# Altium schematic sheet remote_controll_main(V1.3).SchDoc(remote_controll_main(V1.3))
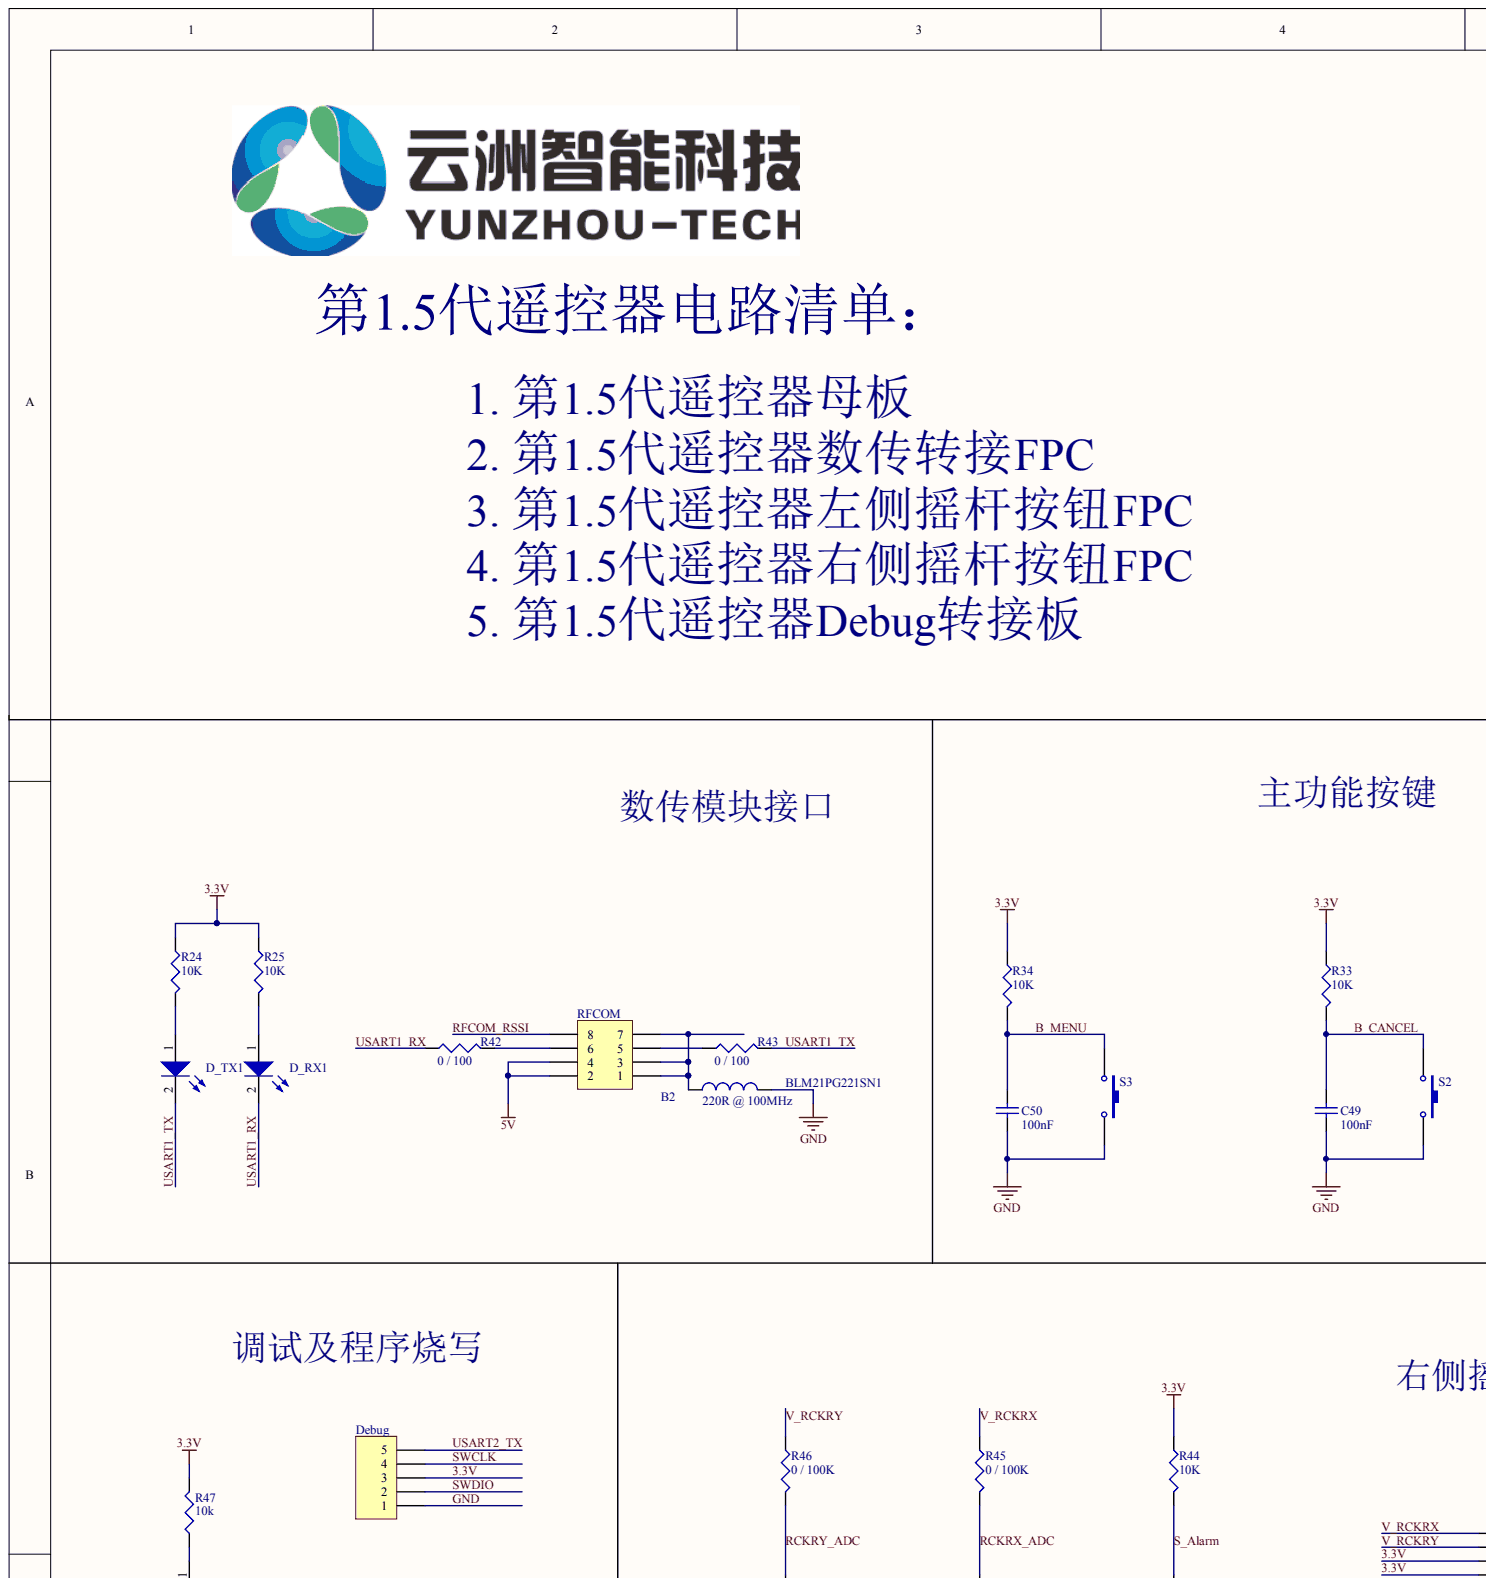
[diagram p0: remote_controll_main, top left]
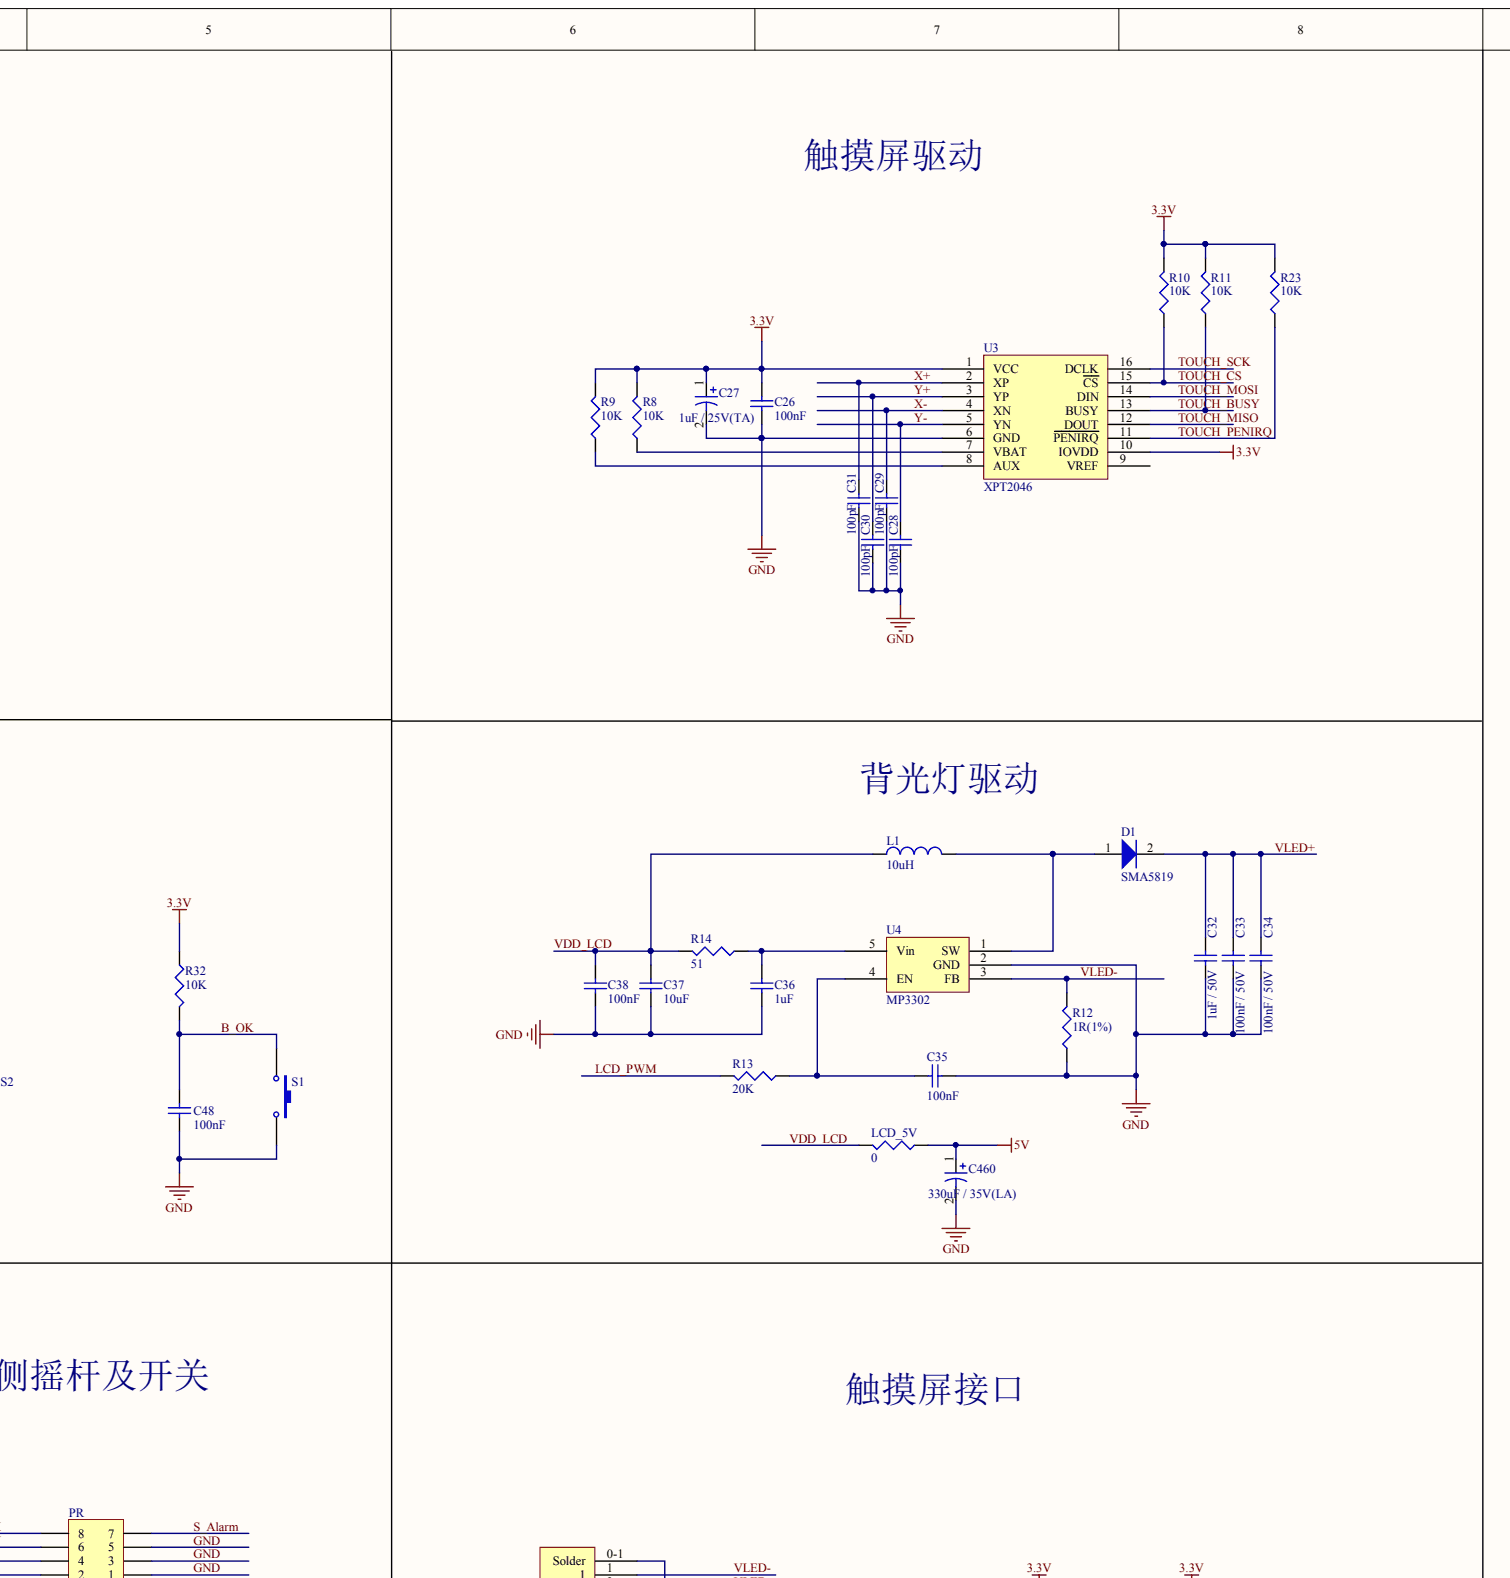
[diagram p1: remote_controll_main, top centre]
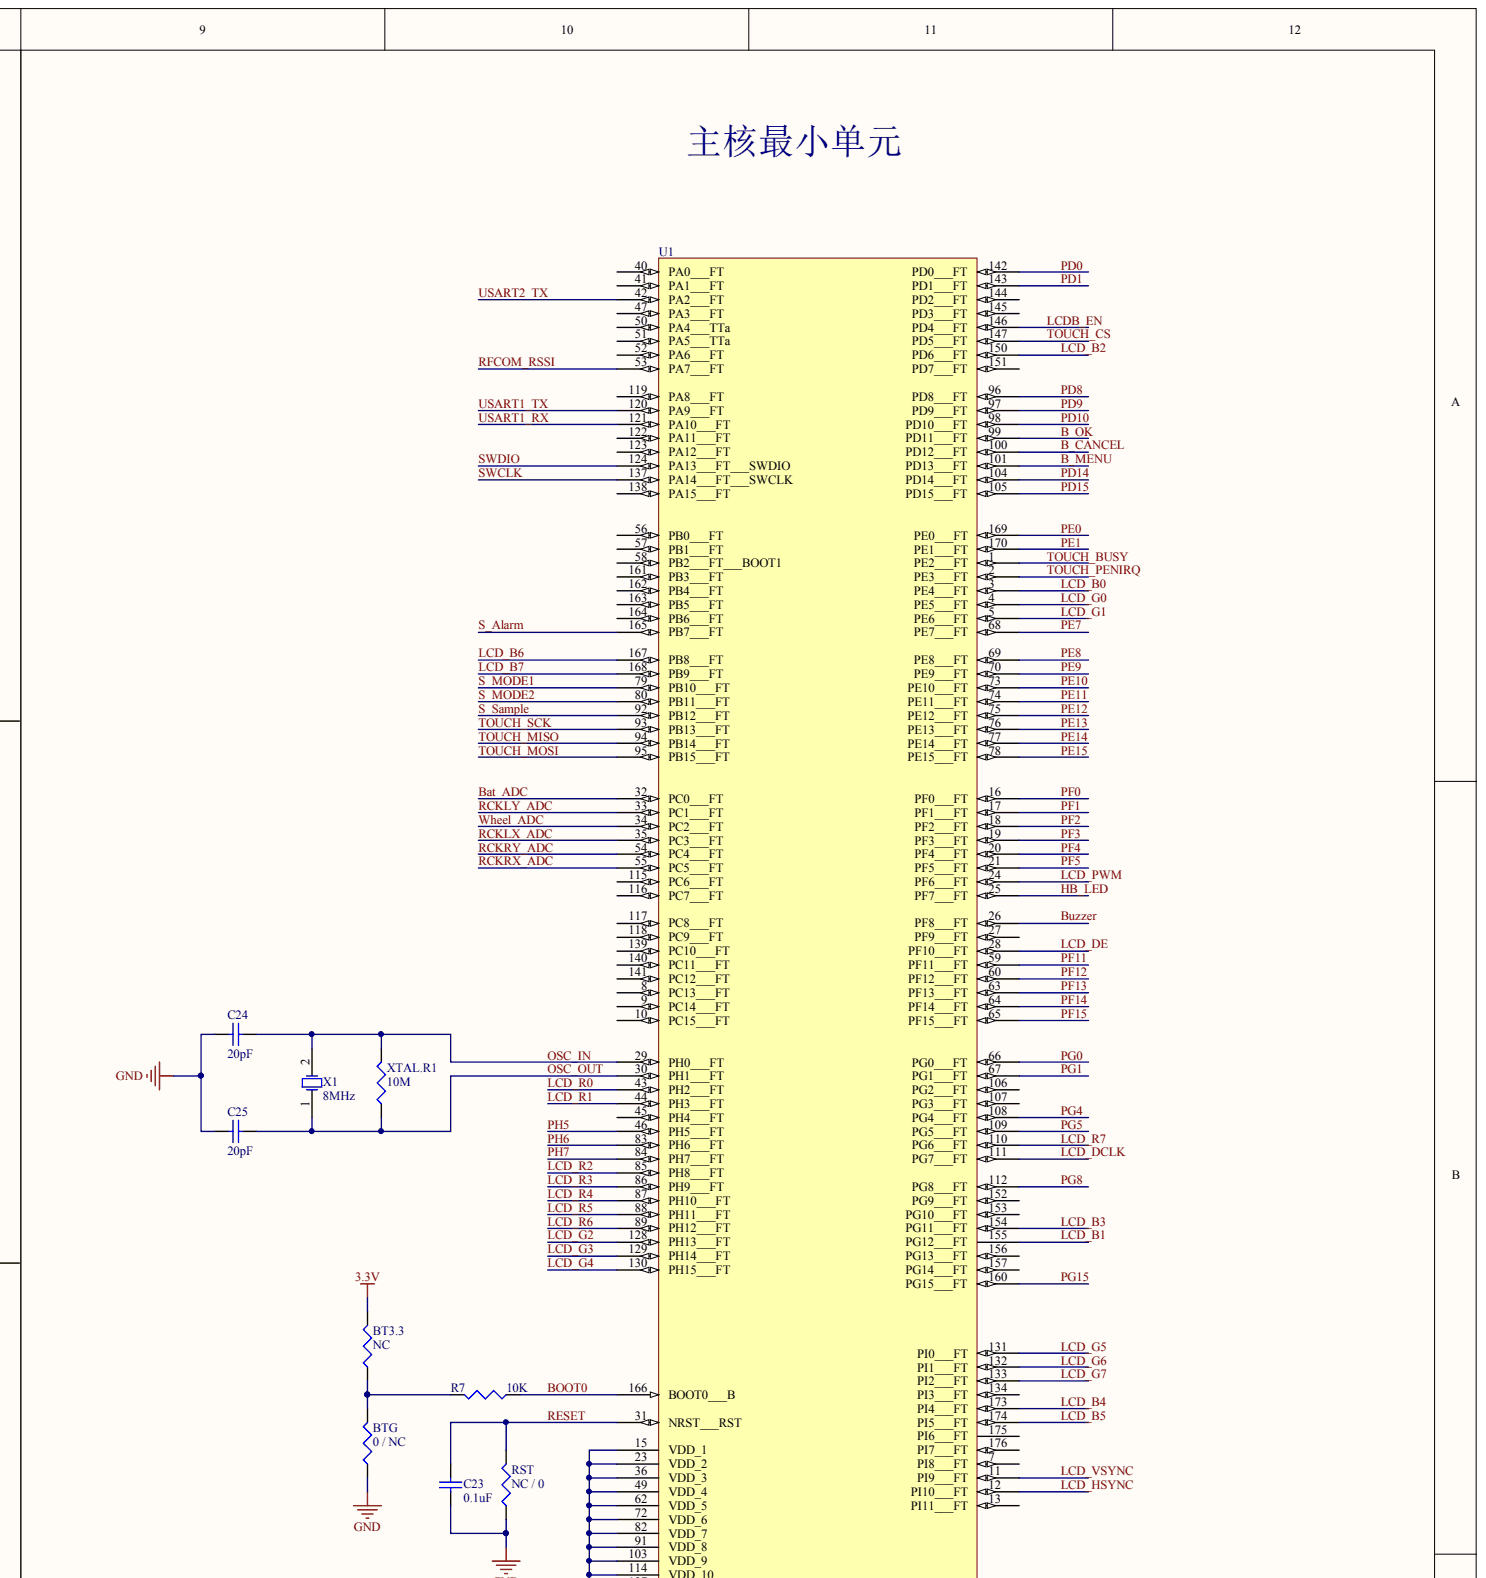
[diagram p2: remote_controll_main, top right]
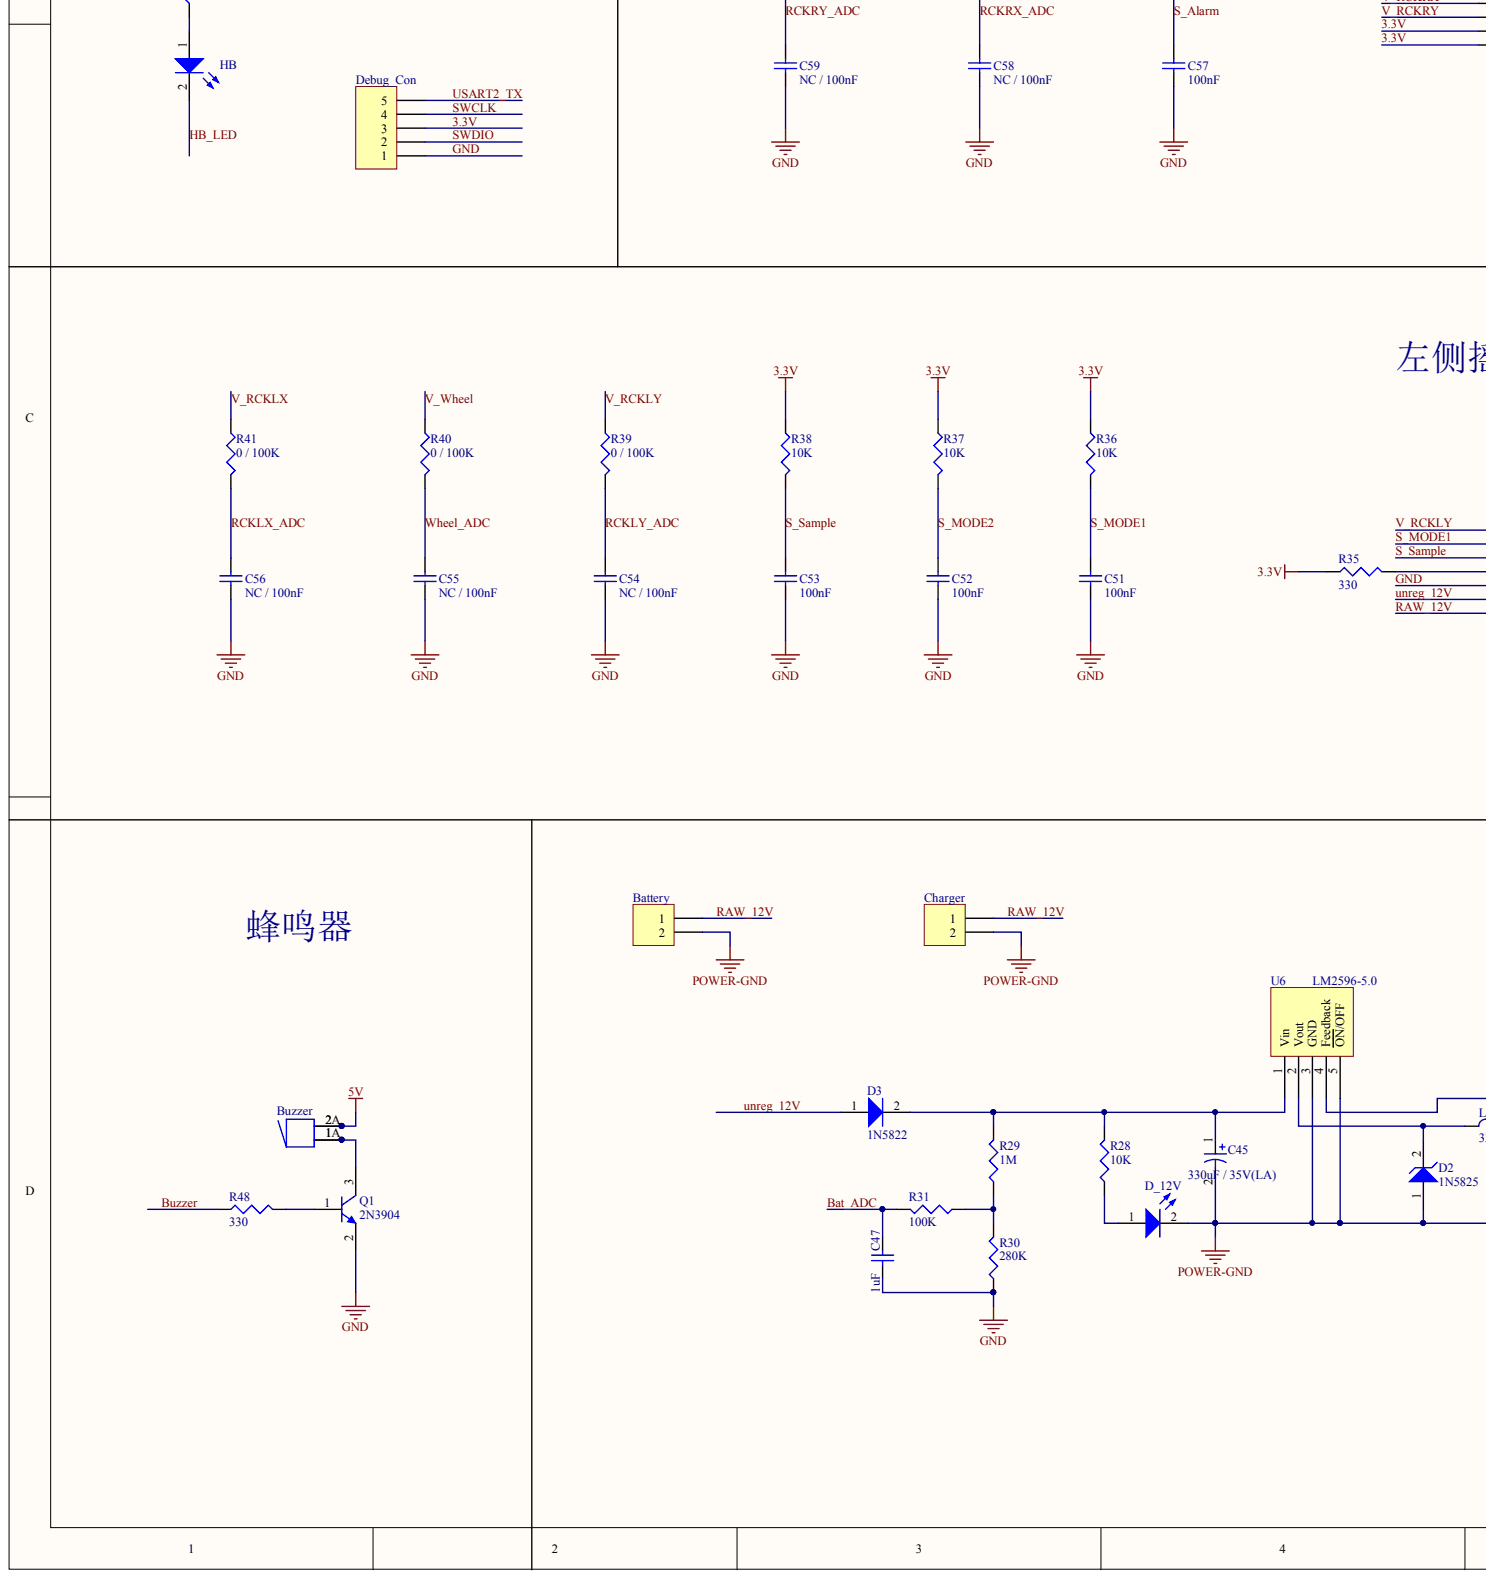
[diagram p3: remote_controll_main, bottom left]
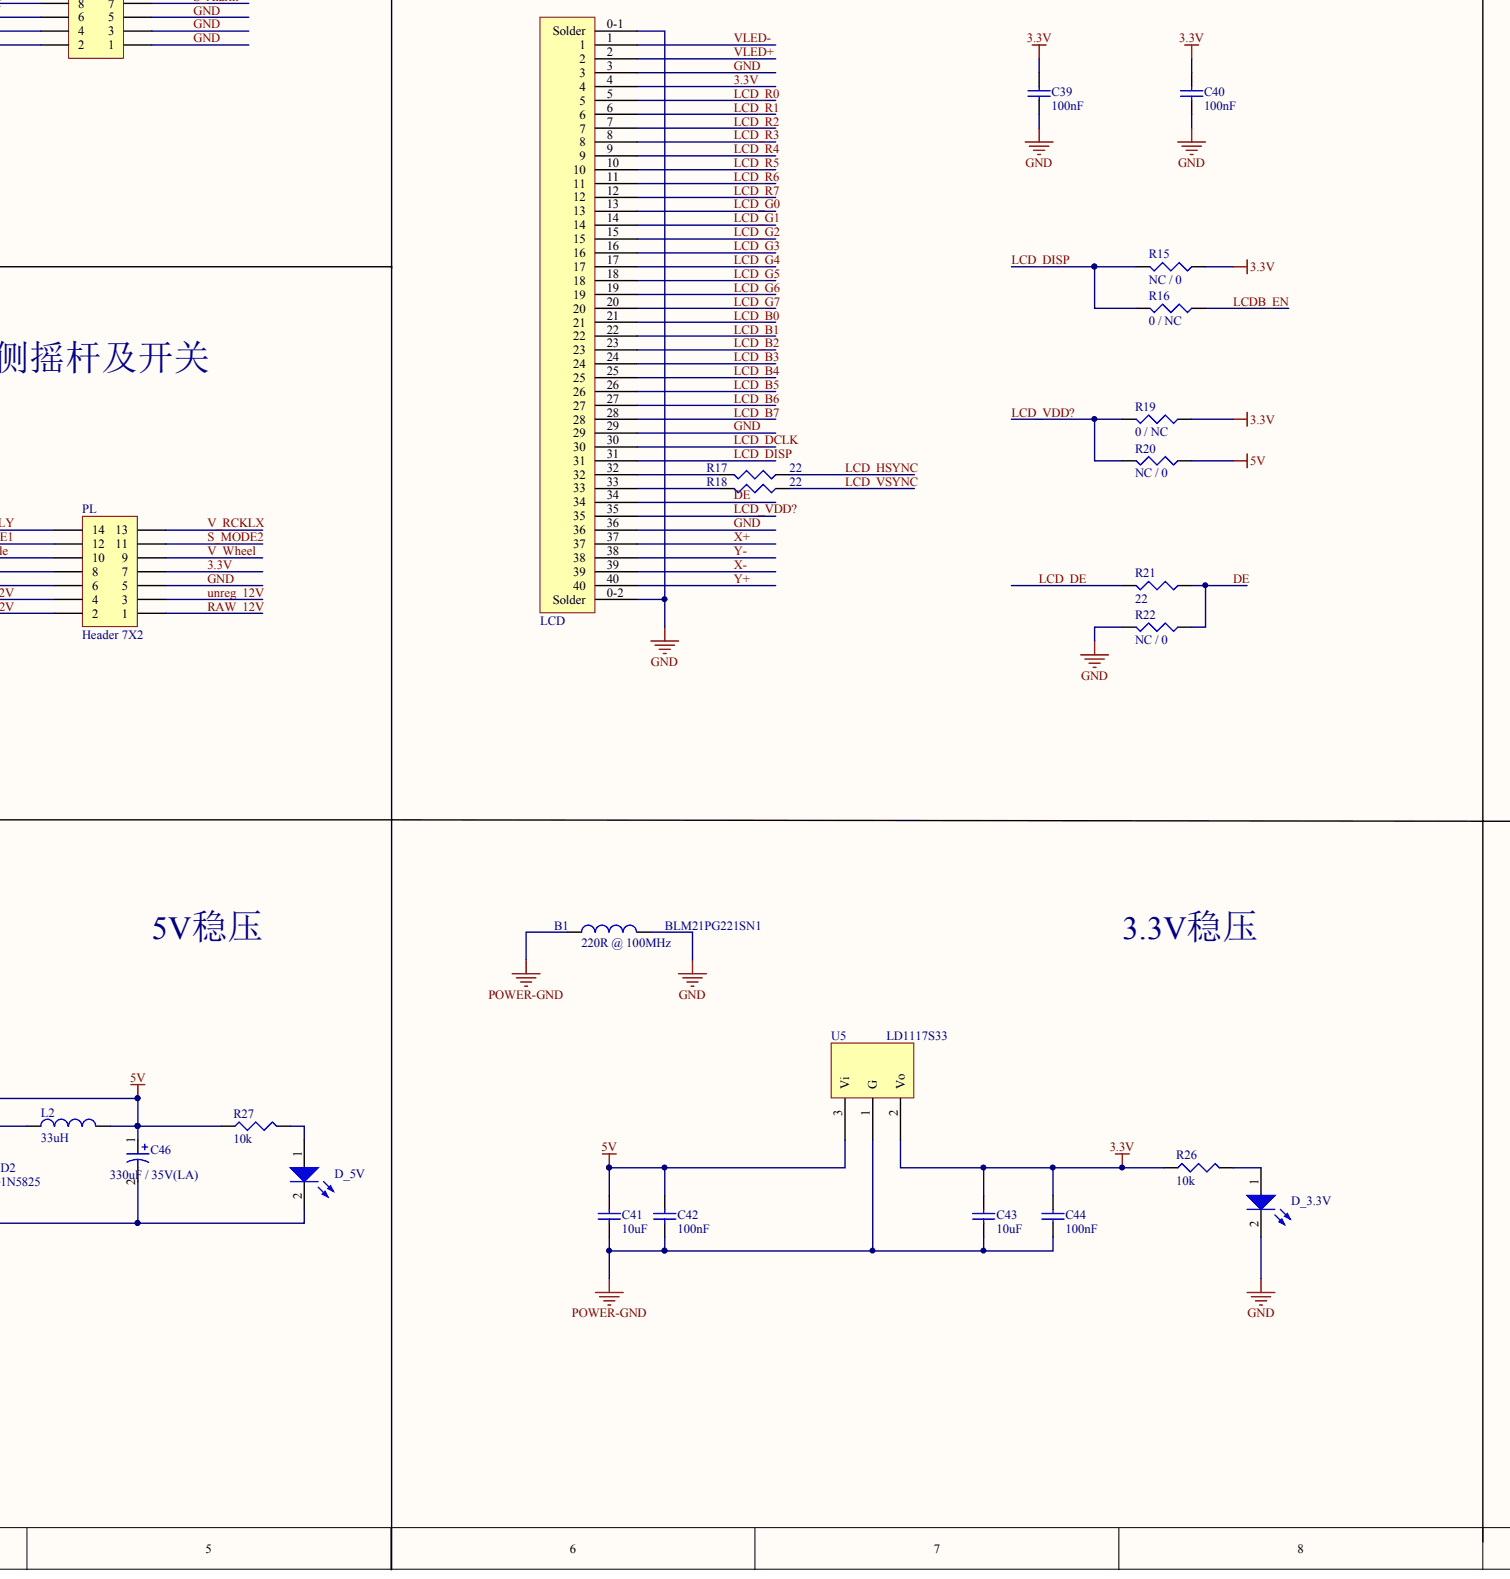
[diagram p4: remote_controll_main, bottom centre]
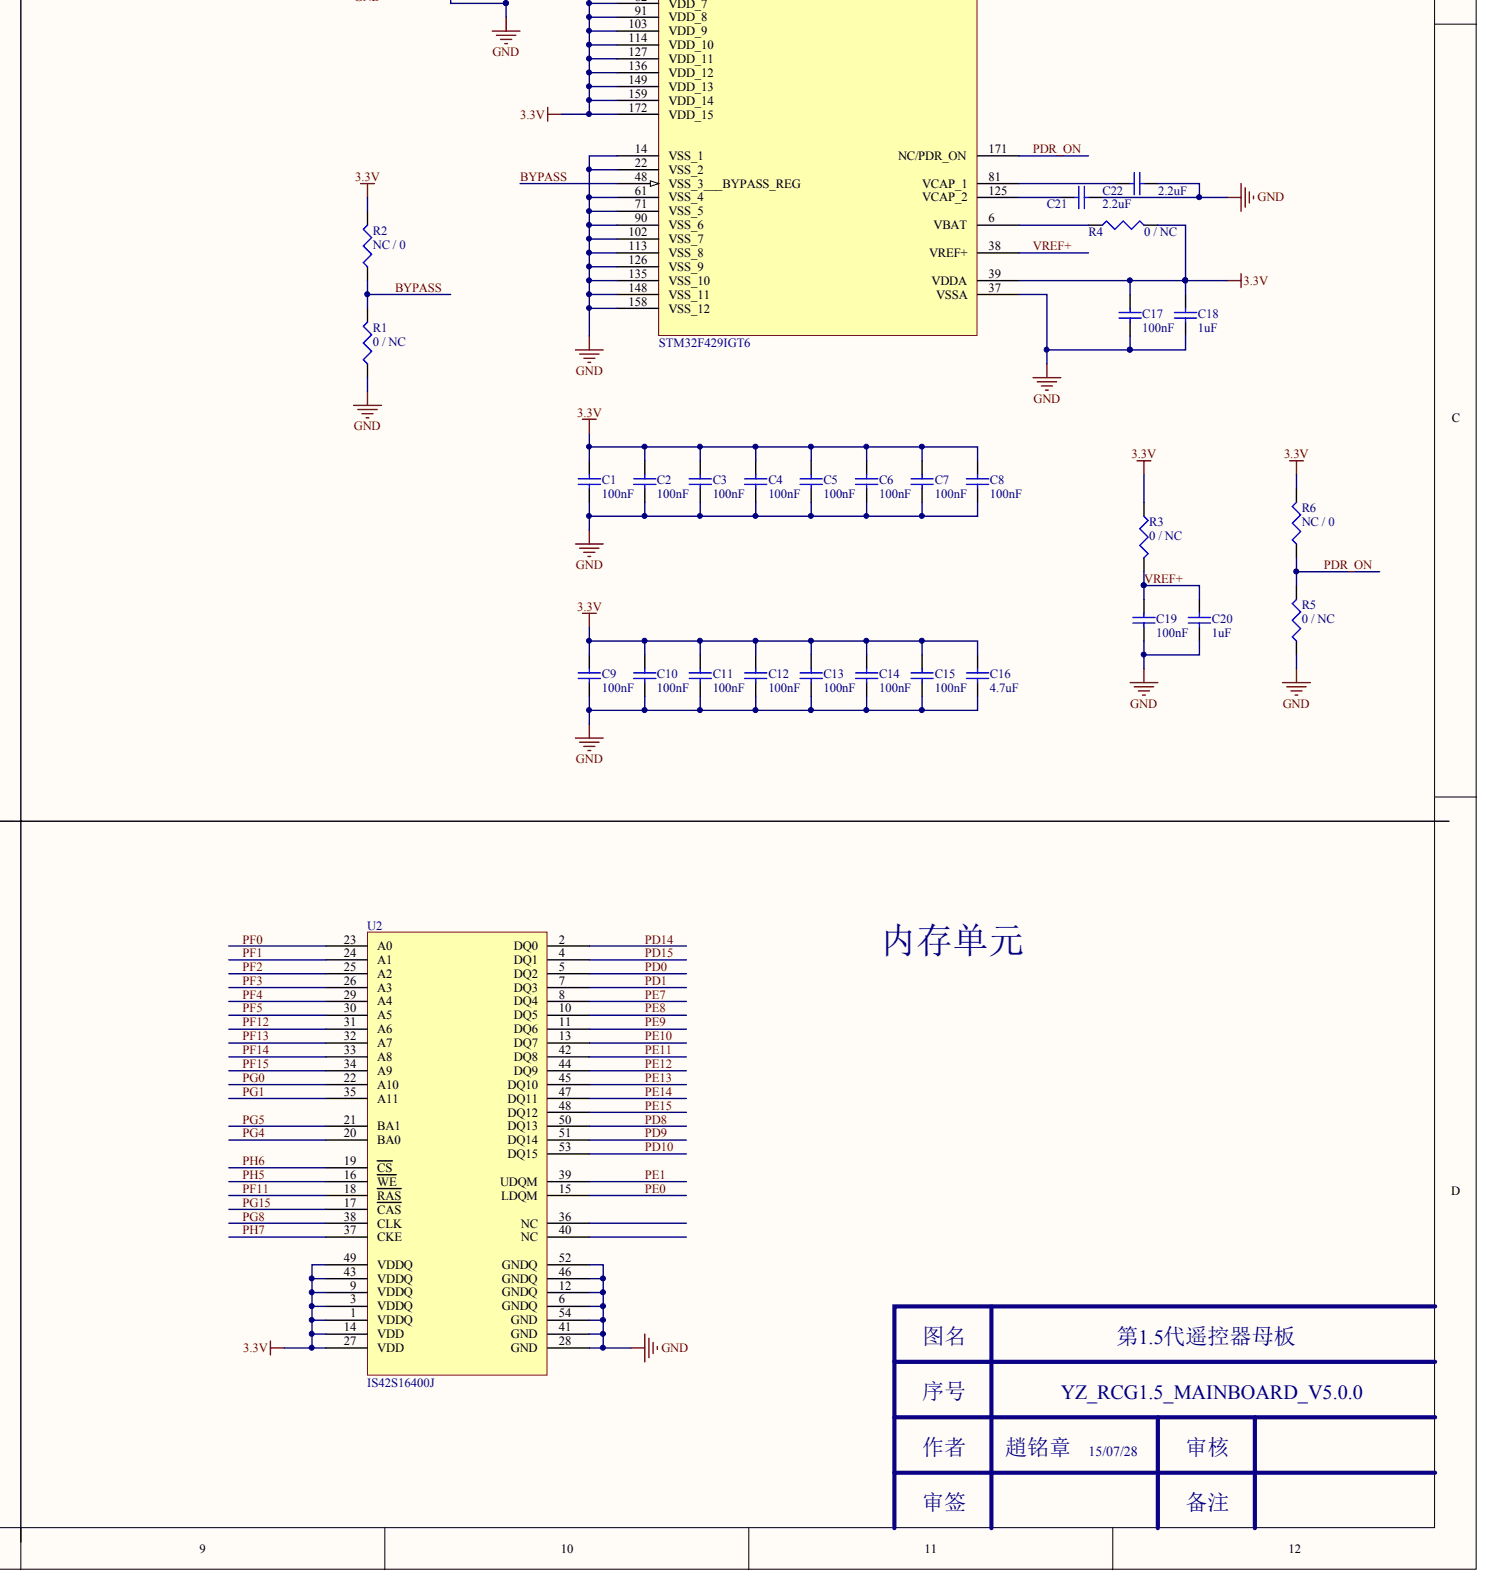
[diagram p5: remote_controll_main, bottom right]

## Parts
B1  BLM21PG221SN1  value 220R @ 100MHz
B2  BLM21PG221SN1  value 220R @ 100MHz
Battery  Header 2  (value not drawn)
BT3.3  value NC  (hidden comment: Res3)
BTG  value 0 / NC  (hidden comment: Res3)
Buzzer  Buzzer
C1  value 100nF  (hidden comment: Cap Semi)
C2  value 100nF  (hidden comment: Cap Semi)
C3  value 100nF  (hidden comment: Cap Semi)
C4  value 100nF  (hidden comment: Cap Semi)
C5  value 100nF  (hidden comment: Cap Semi)
C6  value 100nF  (hidden comment: Cap Semi)
C7  value 100nF  (hidden comment: Cap Semi)
C8  value 100nF  (hidden comment: Cap Semi)
C9  value 100nF  (hidden comment: Cap Semi)
C10  value 100nF  (hidden comment: Cap Semi)
C11  value 100nF  (hidden comment: Cap Semi)
C12  value 100nF  (hidden comment: Cap Semi)
C13  value 100nF  (hidden comment: Cap Semi)
C14  value 100nF  (hidden comment: Cap Semi)
C15  value 100nF  (hidden comment: Cap Semi)
C16  value 4.7uF  (hidden comment: Cap Semi)
C17  value 100nF  (hidden comment: Cap Semi)
C18  value 1uF  (hidden comment: Cap Semi)
C19  value 100nF  (hidden comment: Cap Semi)
C20  value 1uF  (hidden comment: Cap Semi)
C21  value 2.2uF  (hidden comment: Cap Semi)
C22  value 2.2uF  (hidden comment: Cap Semi)
C23  value 0.1uF  (hidden comment: Cap Semi)
C24  value 20pF  (hidden comment: Cap Semi)
C25  value 20pF  (hidden comment: Cap Semi)
C26  value 100nF  (hidden comment: Cap Semi)
C27  value 1uF / 25V(TA)  (hidden comment: Cap Pol3)
C28  value 100pF  (hidden comment: Cap Semi)
C29  value 100pF  (hidden comment: Cap Semi)
C30  value 100pF  (hidden comment: Cap Semi)
C31  value 100pF  (hidden comment: Cap Semi)
C32  value 1uF / 50V  (hidden comment: Cap Semi)
C33  value 100nF / 50V  (hidden comment: Cap Semi)
C34  value 100nF / 50V  (hidden comment: Cap Semi)
C35  value 100nF  (hidden comment: Cap Semi)
C36  value 1uF  (hidden comment: Cap Semi)
C37  value 10uF  (hidden comment: Cap Semi)
C38  value 100nF  (hidden comment: Cap Semi)
C39  value 100nF  (hidden comment: Cap Semi)
C40  value 100nF  (hidden comment: Cap Semi)
C41  value 10uF  (hidden comment: Cap Semi)
C42  value 100nF  (hidden comment: Cap Semi)
C43  value 10uF  (hidden comment: Cap Semi)
C44  value 100nF  (hidden comment: Cap Semi)
C45  value 330uF / 35V(LA)  (hidden comment: Cap Pol3)
C46  value 330uF / 35V(LA)  (hidden comment: Cap Pol3)
C47  value 1uF  (hidden comment: Cap Semi)
C48  value 100nF  (hidden comment: Cap Semi)
C49  value 100nF  (hidden comment: Cap Semi)
C50  value 100nF  (hidden comment: Cap Semi)
C51  value 100nF  (hidden comment: Cap Semi)
C52  value 100nF  (hidden comment: Cap Semi)
C53  value 100nF  (hidden comment: Cap Semi)
C54  value NC / 100nF  (hidden comment: Cap Semi)
C55  value NC / 100nF  (hidden comment: Cap Semi)
C56  value NC / 100nF  (hidden comment: Cap Semi)
C57  value 100nF  (hidden comment: Cap Semi)
C58  value NC / 100nF  (hidden comment: Cap Semi)
C59  value NC / 100nF  (hidden comment: Cap Semi)
C460  value 330uF / 35V(LA)  (hidden comment: Cap Pol3)
Charger  Header 2  (value not drawn)
D1  SMA5819
D2  1N5825
D3  1N5822
D_3.3V  LED3  (value not drawn)
D_5V  LED3  (value not drawn)
D_12V  LED3  (value not drawn)
D_RX1  LED3  (value not drawn)
D_TX1  LED3  (value not drawn)
Debug  Debug
Debug_Con  Debug
HB  LED3  (value not drawn)
L1  value 10uH  (hidden comment: Inductor)
L2  value 33uH  (hidden comment: Inductor)
LCD  FPC_40  (value not drawn)
LCD_5V  value 0  (hidden comment: Res3)
PL  Header 7X2
PR  Header 4X2  (value not drawn)
Q1  2N3904
R1  value 0 / NC  (hidden comment: Res3)
R2  value NC / 0  (hidden comment: Res3)
R3  value 0 / NC  (hidden comment: Res3)
R4  value 0 / NC  (hidden comment: Res3)
R5  value 0 / NC  (hidden comment: Res3)
R6  value NC / 0  (hidden comment: Res3)
R7  value 10K  (hidden comment: Res3)
R8  value 10K  (hidden comment: Res3)
R9  value 10K  (hidden comment: Res3)
R10  value 10K  (hidden comment: Res3)
R11  value 10K  (hidden comment: Res3)
R12  value 1R(1%)  (hidden comment: Res3)
R13  value 20K  (hidden comment: Res3)
R14  value 51  (hidden comment: Res3)
R15  value NC / 0  (hidden comment: Res3)
R16  value 0 / NC  (hidden comment: Res3)
R17  value 22  (hidden comment: Res3)
R18  value 22  (hidden comment: Res3)
R19  value 0 / NC  (hidden comment: Res3)
R20  value NC / 0  (hidden comment: Res3)
R21  value 22  (hidden comment: Res3)
R22  value NC / 0  (hidden comment: Res3)
R23  value 10K  (hidden comment: Res3)
R24  value 10K  (hidden comment: Res3)
R25  value 10K  (hidden comment: Res3)
R26  value 10k  (hidden comment: Res3)
R27  value 10k  (hidden comment: Res3)
R28  value 10K  (hidden comment: Res3)
R29  value 1M  (hidden comment: Res3)
R30  value 280K  (hidden comment: Res3)
R31  value 100K  (hidden comment: Res3)
R32  value 10K  (hidden comment: Res3)
R33  value 10K  (hidden comment: Res3)
R34  value 10K  (hidden comment: Res3)
R35  value 330  (hidden comment: Res3)
R36  value 10K  (hidden comment: Res3)
R37  value 10K  (hidden comment: Res3)
R38  value 10K  (hidden comment: Res3)
R39  value 0 / 100K  (hidden comment: Res3)
R40  value 0 / 100K  (hidden comment: Res3)
R41  value 0 / 100K  (hidden comment: Res3)
R42  value 0 / 100  (hidden comment: Res3)
R43  value 0 / 100  (hidden comment: Res3)
R44  value 10K  (hidden comment: Res3)
R45  value 0 / 100K  (hidden comment: Res3)
R46  value 0 / 100K  (hidden comment: Res3)
R47  value 10k  (hidden comment: Res3)
R48  value 330  (hidden comment: Res3)
RFCOM  Header 4X2  (value not drawn)
RST  value NC / 0  (hidden comment: Res3)
S1  SW-PB  (value not drawn)
S2  SW-PB  (value not drawn)
S3  SW-PB  (value not drawn)
U1  STM32F429IGT6
U2  IS42S16400J
U3  XPT2046
U4  MP3302
U5  LD1117S33
U6  LM2596-5.0
X1  8MHz
XTAL.R1  value 10M  (hidden comment: Res3)

## Nets
5V: Buzzer.2 Buzzer.2A C41.1 C42.1 C46.1 C460.1 L2.2 LCD_5V.1 R20.1 R27.1 RFCOM.2 RFCOM.4 U5.3 U6.4(Feedback)
POWER-GND: B1.1 Battery.2 C41.2 C42.2 C43.2 C44.2 C45.2 C46.2 Charger.2 D2.1 D_5V.2 D_12V.2 U5.1 U6.3(GND) U6.5(ON/OFF)
3.3V: BT3.3.2 C1.2 C2.2 C3.2 C4.2 C5.2 C6.2 C7.2 C8.2 C9.2 C10.2 C11.2 C12.2 C13.2 C14.2 C15.2 C16.2 C17.2 C18.2 C26.2 C27.1 C39.1 C40.1 C43.1 C44.1 Debug.3 Debug_Con.3 LCD.4 PL.7 PR.2 PR.4 R2.2 R3.1 R4.2 R6.2 R8.2 R9.2 R10.2 R11.2 R15.1 R19.1 R23.2 R24.1 R25.1 R26.1 R32.1 R33.1 R34.1 R35.1 R36.1 R37.1 R38.1 R44.1 R47.2 U1.15(VDD_1) U1.23(VDD_2) U1.36(VDD_3) U1.39(VDDA) U1.49(VDD_4) U1.62(VDD_5) U1.72(VDD_6) U1.82(VDD_7) U1.91(VDD_8) U1.103(VDD_9) U1.114(VDD_10) U1.127(VDD_11) U1.136(VDD_12) U1.149(VDD_13) U1.159(VDD_14) U1.172(VDD_15) U2.1(VDDQ) U2.3(VDDQ) U2.9(VDDQ) U2.14(VDD) U2.27(VDD) U2.43(VDDQ) U2.49(VDDQ) U3.1(VCC) U3.10(IOVDD) U5.2
B_CANCEL: C49.2 R33.2 S2.1 U1.100(PD12___FT)
B_MENU: C50.2 R34.2 S3.1 U1.101(PD13___FT)
B_OK: C48.2 R32.2 S1.1 U1.99(PD11___FT)
BAT_ADC: C47.2 R31.2 U1.32(PC0___FT)
BOOT0: R7.1 U1.166(BOOT0___B)
BUZZER: R48.1 U1.26(PF8___FT)
BYPASS: R1.2 R2.1 U1.48(VSS_3___BYPASS_REG)
DE: LCD.34 R21.1 R22.1
GND: B1.2 B2.2 BTG.1 C1.1 C2.1 C3.1 C4.1 C5.1 C6.1 C7.1 C8.1 C9.1 C10.1 C11.1 C12.1 C13.1 C14.1 C15.1 C16.1 C17.1 C18.1 C19.1 C20.1 C21.1 C22.1 C23.2 C24.1 C25.1 C26.1 C27.2 C28.1 C29.1 C30.1 C31.1 C32.1 C33.1 C34.1 C35.1 C36.2 C37.2 C38.2 C39.2 C40.2 C47.1 C48.1 C49.1 C50.1 C51.1 C52.1 C53.1 C54.1 C55.1 C56.1 C57.1 C58.1 C59.1 C460.2 D_3.3V.2 Debug.1 Debug_Con.1 LCD.0-1(Solder) LCD.0-2(Solder) LCD.3 LCD.29 LCD.36 PL.5 PL.6 PR.1 PR.3 PR.5 Q1.2 R1.1 R5.1 R12.1 R22.2 R30.1 RST.1 S1.2 S2.2 S3.2 U1.14(VSS_1) U1.22(VSS_2) U1.37(VSSA) U1.61(VSS_4) U1.71(VSS_5) U1.90(VSS_6) U1.102(VSS_7) U1.113(VSS_8) U1.126(VSS_9) U1.135(VSS_10) U1.148(VSS_11) U1.158(VSS_12) U2.6(GNDQ) U2.12(GNDQ) U2.28(GND) U2.41(GND) U2.46(GNDQ) U2.52(GNDQ) U2.54(GND) U3.6(GND) U4.2(GND)
HB_LED: HB.2 U1.25(PF7___FT)
LCD_B0: LCD.21 U1.3(PE4___FT)
LCD_B1: LCD.22 U1.155(PG12___FT)
LCD_B2: LCD.23 U1.150(PD6___FT)
LCD_B3: LCD.24 U1.154(PG11___FT)
LCD_B4: LCD.25 U1.173(PI4___FT)
LCD_B5: LCD.26 U1.174(PI5___FT)
LCD_B6: LCD.27 U1.167(PB8___FT)
LCD_B7: LCD.28 U1.168(PB9___FT)
LCD_DCLK: LCD.30 U1.111(PG7___FT)
LCD_DE: R21.2 U1.28(PF10___FT)
LCD_DISP: LCD.31 R15.2 R16.2
LCD_G0: LCD.13 U1.4(PE5___FT)
LCD_G1: LCD.14 U1.5(PE6___FT)
LCD_G2: LCD.15 U1.128(PH13___FT)
LCD_G3: LCD.16 U1.129(PH14___FT)
LCD_G4: LCD.17 U1.130(PH15___FT)
LCD_G5: LCD.18 U1.131(PI0___FT)
LCD_G6: LCD.19 U1.132(PI1___FT)
LCD_G7: LCD.20 U1.133(PI2___FT)
LCD_HSYNC: R17.1 U1.12
LCD_PWM: R13.2 U1.24(PF6___FT)
LCD_R0: LCD.5 U1.43(PH2___FT)
LCD_R1: LCD.6 U1.44(PH3___FT)
LCD_R2: LCD.7 U1.85(PH8___FT)
LCD_R3: LCD.8 U1.86(PH9___FT)
LCD_R4: LCD.9 U1.87(PH10___FT)
LCD_R5: LCD.10 U1.88(PH11___FT)
LCD_R6: LCD.11 U1.89(PH12___FT)
LCD_R7: LCD.12 U1.110(PG6___FT)
LCD_VDD?: LCD.35 R19.2 R20.2
LCD_VSYNC: R18.1 U1.11(PI9___FT)
LCDB_EN: R16.1 U1.146(PD4___FT)
OSC_IN: C24.2 U1.29(PH0___FT) X1.2 XTAL.R1.2
OSC_OUT: C25.2 U1.30(PH1___FT) X1.1 XTAL.R1.1
PD0: U1.142(PD0___FT) U2.5(DQ2)
PD1: U1.143(PD1___FT) U2.7(DQ3)
PD8: U1.96(PD8___FT) U2.50(DQ13)
PD9: U1.97(PD9___FT) U2.51(DQ14)
PD10: U1.98(PD10___FT) U2.53(DQ15)
PD14: U1.104(PD14___FT) U2.2(DQ0)
PD15: U1.105(PD15___FT) U2.4(DQ1)
PDR_ON: R5.2 R6.1 U1.171(NC/PDR_ON)
PE0: U1.169(PE0___FT) U2.15(LDQM)
PE1: U1.170(PE1___FT) U2.39(UDQM)
PE7: U1.68(PE7___FT) U2.8(DQ4)
PE8: U1.69(PE8___FT) U2.10(DQ5)
PE9: U1.70(PE9___FT) U2.11(DQ6)
PE10: U1.73(PE10___FT) U2.13(DQ7)
PE11: U1.74(PE11___FT) U2.42(DQ8)
PE12: U1.75(PE12___FT) U2.44(DQ9)
PE13: U1.76(PE13___FT) U2.45(DQ10)
PE14: U1.77(PE14___FT) U2.47(DQ11)
PE15: U1.78(PE15___FT) U2.48(DQ12)
PF0: U1.16(PF0___FT) U2.23(A0)
PF1: U1.17(PF1___FT) U2.24(A1)
PF2: U1.18(PF2___FT) U2.25(A2)
PF3: U1.19(PF3___FT) U2.26(A3)
PF4: U1.20(PF4___FT) U2.29(A4)
PF5: U1.21(PF5___FT) U2.30(A5)
PF11: U1.59(PF11___FT) U2.18(RAS)
PF12: U1.60(PF12___FT) U2.31(A6)
PF13: U1.63(PF13___FT) U2.32(A7)
PF14: U1.64(PF14___FT) U2.33(A8)
PF15: U1.65(PF15___FT) U2.34(A9)
PG0: U1.66(PG0___FT) U2.22(A10)
PG1: U1.67(PG1___FT) U2.35(A11)
PG4: U1.108(PG4___FT) U2.20(BA0)
PG5: U1.109(PG5___FT) U2.21(BA1)
PG8: U1.112(PG8___FT) U2.38(CLK)
PG15: U1.160(PG15___FT) U2.17(CAS)
PH5: U1.46(PH5___FT) U2.16(WE)
PH6: U1.83(PH6___FT) U2.19(CS)
PH7: U1.84(PH7___FT) U2.37(CKE)
RAW_12V: Battery.1 Charger.1 PL.1 PL.2
RCKLX_ADC: C56.2 R41.2 U1.35(PC3___FT)
RCKLY_ADC: C54.2 R39.2 U1.33(PC1___FT)
RCKRX_ADC: C58.2 R45.2 U1.55(PC5___FT)
RCKRY_ADC: C59.2 R46.2 U1.54(PC4___FT)
RESET: C23.1 RST.2 U1.31(NRST___RST)
RFCOM_RSSI: RFCOM.8 U1.53(PA7___FT)
S_ALARM: C57.2 PR.7 R44.2 U1.165(PB7___FT)
S_MODE1: C51.2 PL.12 R36.2 U1.79(PB10___FT)
S_MODE2: C52.2 PL.11 R37.2 U1.80(PB11___FT)
S_SAMPLE: C53.2 PL.10 R38.2 U1.92(PB12___FT)
SWCLK: Debug.4 Debug_Con.4 U1.137(PA14___FT___SWCLK)
SWDIO: Debug.2 Debug_Con.2 U1.124(PA13___FT___SWDIO)
TOUCH_BUSY: R11.1 U1.1(PE2___FT) U3.13(BUSY)
TOUCH_CS: R10.1 U1.147(PD5___FT) U3.15(CS)
TOUCH_MISO: U1.94(PB14___FT) U3.12(DOUT)
TOUCH_MOSI: U1.95(PB15___FT) U3.14(DIN)
TOUCH_PENIRQ: R23.1 U1.2(PE3___FT) U3.11(PENIRQ)
TOUCH_SCK: U1.93(PB13___FT) U3.16(DCLK)
UNREG_12V: D3.1 PL.3 PL.4
USART1_RX: D_RX1.2 R42.1 U1.121(PA10___FT)
USART1_TX: D_TX1.2 R43.2 U1.120(PA9___FT)
USART2_TX: Debug.5 Debug_Con.5 U1.42(PA2___FT)
V_RCKLX: PL.13 R41.1
V_RCKLY: PL.14 R39.1
V_RCKRX: PR.8 R45.1
V_RCKRY: PR.6 R46.1
V_WHEEL: PL.9 R40.1
VDD_LCD: C37.1 C38.1 L1.1 LCD_5V.2 R14.2
VLED+: C32.2 C33.2 C34.2 D1.2 LCD.2
VLED-: LCD.1 R12.2 U4.3(FB)
VREF+: C19.2 C20.2 R3.2 U1.38(VREF+)
WHEEL_ADC: C55.2 R40.2 U1.34(PC2___FT)
X+: C31.2 LCD.37 U3.2(XP)
X-: C29.2 LCD.39 U3.4(XN)
Y+: C30.2 LCD.40 U3.3(YP)
Y-: C28.2 LCD.38 U3.5(YN)
NetB2_1: B2.1 RFCOM.1 RFCOM.3 RFCOM.7
NetBT3.3_1: BT3.3.1 BTG.2 R7.2
NetBuzzer_1: Buzzer.1 Buzzer.1A Q1.3
NetC21_2: C21.2 U1.125(VCAP_2)
NetC22_2: C22.2 U1.81(VCAP_1)
NetC35_2: C35.2 R13.1 U4.4(EN)
NetC36_1: C36.1 R14.1 U4.5(Vin)
NetC45_1: C45.1 D3.2 R28.2 R29.2 U6.1(Vin)
NetD1_1: D1.1 L1.2 U4.1(SW)
NetD2_2: D2.2 L2.1 U6.2(Vout)
NetD_3.3V_1: D_3.3V.1 R26.2
NetD_5V_1: D_5V.1 R27.2
NetD_12V_1: D_12V.1 R28.1
NetD_RX1_1: D_RX1.1 R25.2
NetD_TX1_1: D_TX1.1 R24.2
NetHB_1: HB.1 R47.1
NetLCD_32: LCD.32 R17.2
NetLCD_33: LCD.33 R18.2
NetPL_8: PL.8 R35.2
NetQ1_1: Q1.1 R48.2
NetR4_1: R4.1 U1.6(VBAT)
NetR8_1: R8.1 U3.7(VBAT)
NetR9_1: R9.1 U3.8(AUX)
NetR29_1: R29.1 R30.2 R31.1
NetR42_2: R42.2 RFCOM.6
NetR43_1: R43.1 RFCOM.5

Not connected: U1 7(PI8___FT) 8(PC13___FT) 9(PC14___FT) 10(PC15___FT) 13(PI11___FT) 27(PF9___FT) 40(PA0___FT) 41(PA1___FT) 45(PH4___FT) 47(PA3___FT) 50(PA4___TTa) 51(PA5___TTa) 52(PA6___FT) 56(PB0___FT) 57(PB1___FT) 58(PB2___FT___BOOT1) 106(PG2___FT) 107(PG3___FT) 115(PC6___FT) 116(PC7___FT) 117(PC8___FT) 118(PC9___FT) 119(PA8___FT) 122(PA11___FT) 123(PA12___FT) 134(PI3___FT) 138(PA15___FT) 139(PC10___FT) 140(PC11___FT) 141(PC12___FT) 144(PD2___FT) 145(PD3___FT) 151(PD7___FT) 152(PG9___FT) 153(PG10___FT) 156(PG13___FT) 157(PG14___FT) 161(PB3___FT) 162(PB4___FT) 163(PB5___FT) 164(PB6___FT) 175(PI6___FT) 176(PI7___FT); U2 36(NC) 40(NC); U3 9(VREF)

## Library data of the parts
B1 B2 L1 L2 = (no symbol name): Code_IEC: 1005; Published: 8-Jun-2000; LatestRevisionDate: 29-May-2009; LatestRevisionNote: IPC-7351 Footprint Added.; PackageReference: 0402-A; PackageDescription: Chip Inductor; Code_JEITA: 0402; Publisher: Altium Limited
Battery BT3.3 BTG Buzzer C1-C59 C460 Charger D1-D3 D_3.3V D_5V D_12V D_RX1 D_TX1 Debug Debug_Con HB LCD LCD_5V PL PR Q1 R1-R48 RFCOM RST S1-S3 U1-U6 X1 XTAL.R1 = (no symbol name)
  Battery Charger Debug Debug_Con PL PR RFCOM: LatestRevisionDate: 17-Jul-2002; LatestRevisionNote: Re-released for DXP Platform.
  BT3.3 BTG LCD_5V R1-R48 RST XTAL.R1: Published: 8-Jun-2000; LatestRevisionDate: 29-May-2009; LatestRevisionNote: IPC-7351 Footprint Added.; PackageReference: J1-0603; PackageDescription: Chip Resistor
  Buzzer: Published: 24-Mar-1999; LatestRevisionDate: 17-Dec-2003; LatestRevisionNote: Schematic revised, PCB Footprint replaced.; DatasheetVersion: 17-Dec-2003; PackageReference: ABSM-1574; PackageDescription: Magnetic Transducer Buzzer; ComponentLink1Description: Manufacturer Link; ComponentLink1URL: http://www.megastar.com/linecard/buzzmode/index.php
  C1-C26 C28-C44 C47-C59: Published: 8-Jun-2000; LatestRevisionDate: 29-May-2009; LatestRevisionNote: IPC-7351 Footprint Added.; PackageReference: C1206; PackageDescription: Chip Capacitor
  C27 C45 C46 C460: Published: 8-Jun-2000; LatestRevisionDate: 29-May-2009; LatestRevisionNote: IPC-7351 Footprint Added.; PackageReference: C0805; PackageDescription: Chip Capacitor
  D1 D3: Published: 8-Jun-2000; LatestRevisionDate: 17-Jul-2002; LatestRevisionNote: Re-released for DXP Platform.; PackageVersion: Sep-1996; PackageReference: SMC; PackageDescription: DO-214-AB/SMC; 2 C-Bend Leads; Body 7.9 x 5.9 mm, inc. leads (LxW); Code_JEDEC: DO-214-AB
  D2: Published: 8-Jun-2000; LatestRevisionDate: 17-Jul-2002; LatestRevisionNote: Re-released for DXP Platform.; PackageReference: DIODE-0.7; PackageDescription: Diode, Thru-Hole; 2 Leads; 0.7 in Pin Spacing
  D_3.3V D_5V D_12V D_RX1 D_TX1 HB: Published: 8-Jun-2000; LatestRevisionDate: 17-Dec-2003; LatestRevisionNote: PCB Footprint replaced.; DatasheetVersion: 16-Apr-2002; PackageVersion: 16-Apr-2002; PackageReference: 3.5X2.8X1.9; PackageDescription: SM LED; 2 C-Bend Leads; Body 3.5 x 2.8 x 1.9 mm, inc leads (LxWxH typ)
  Q1: Published: 8-Jun-2000; LatestRevisionDate: 15-Jan-2003; LatestRevisionNote: PCB Footprint 'BCY-W3/D4.7' replaced; DatasheetVersion: 1997; PackageVersion: Sep-1998; PackageReference: TO-92A; PackageDescription: TO, Flat Index; 3 In-Line, Axial Leads; Body Dia. 4.5mm; Leads 0.51 x 0.48 mm (max); ComponentLink1Description: Manufacturer Link; ComponentLink1URL: http://www.fairchildsemi.com/; ComponentLink2Description: Datasheet; ComponentLink2URL: http://www.fairchildsemi.com/ds/2N%2F2N3904.pdf
  S1-S3: Published: 24-Mar-1999; LatestRevisionDate: 17-Jul-2002; LatestRevisionNote: Re-released for DXP Platform.; PackageReference: SPST-2; PackageDescription: Switch; 2 Leads
  X1: Published: 8-Jun-2000; LatestRevisionDate: 17-Jul-2002; LatestRevisionNote: Re-released for DXP Platform.; PackageReference: R38; PackageDescription: Crystal, Thru-Hole; 2 Leads; Body 3.1 x 8.2 mm (Dia.xH)
  Battery BT3.3 BTG Buzzer C1-C59 C460 Charger D1-D3 D_3.3V D_5V D_12V D_RX1 D_TX1 Debug Debug_Con HB LCD_5V PL PR Q1 R1-R48 RFCOM RST S1-S3 X1 XTAL.R1: Publisher: Altium Limited
